# Altium schematic sheet MCU_HMI.SchDoc
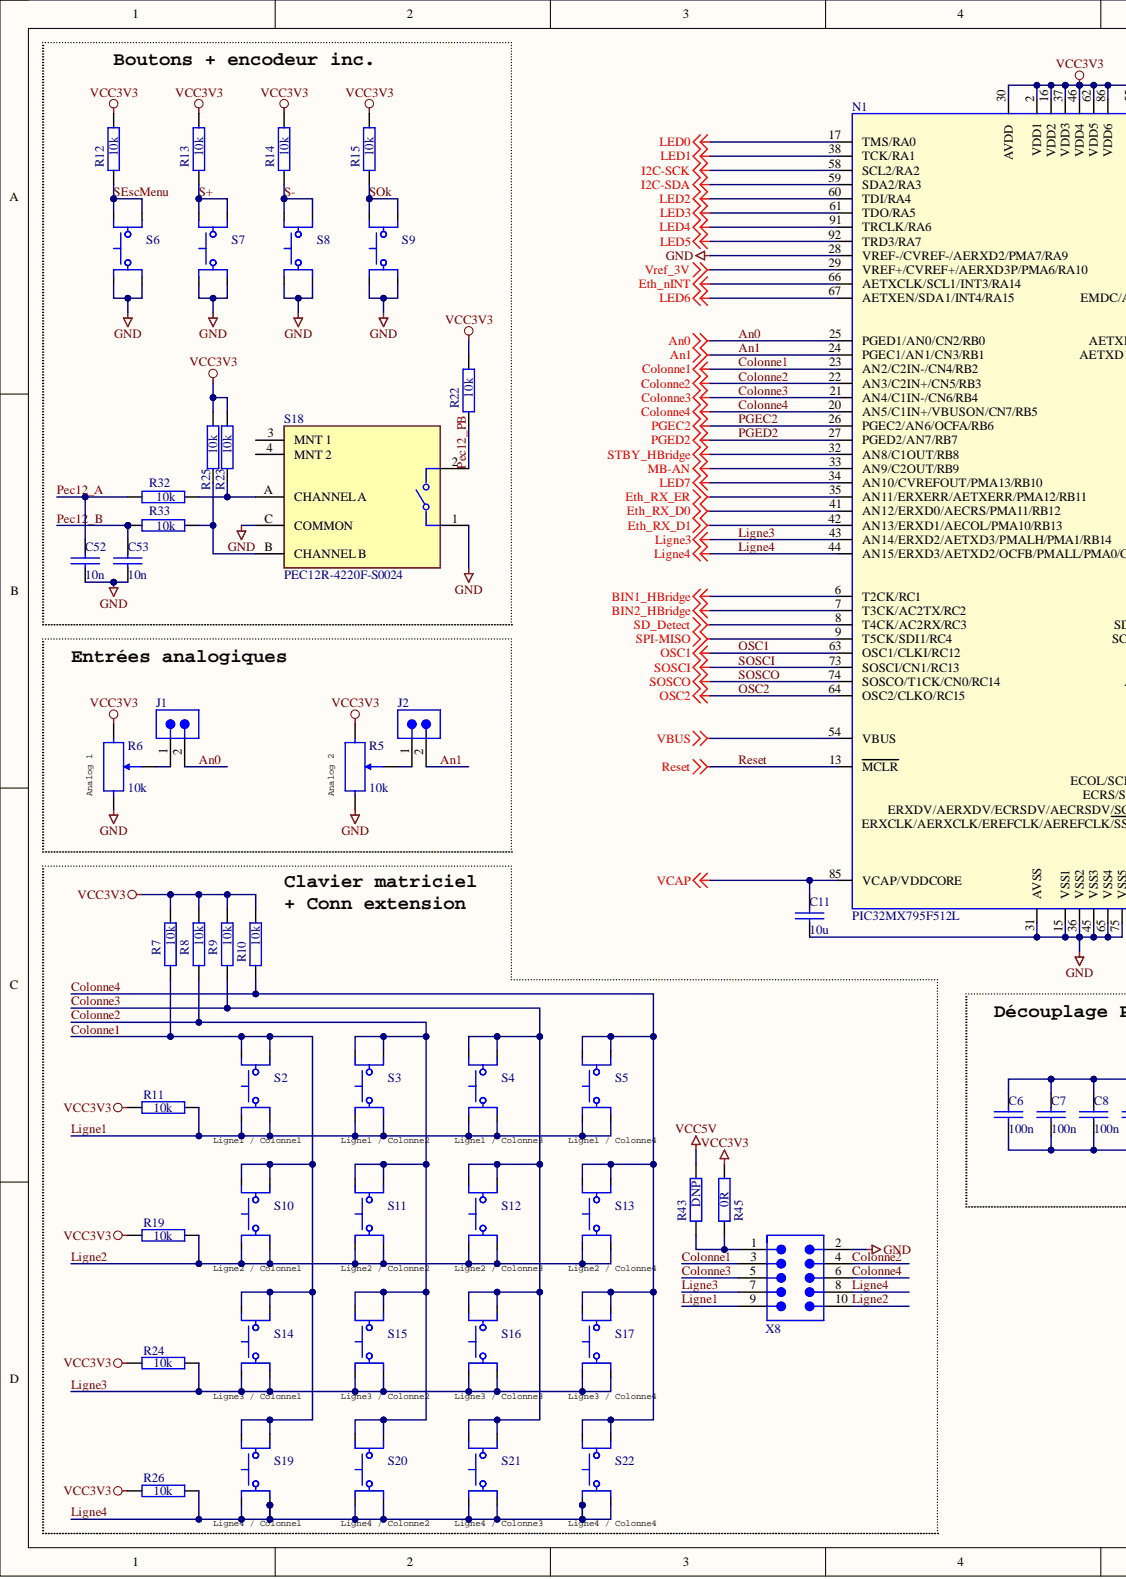
[diagram p0: MCU_HMI.SchDoc, left]
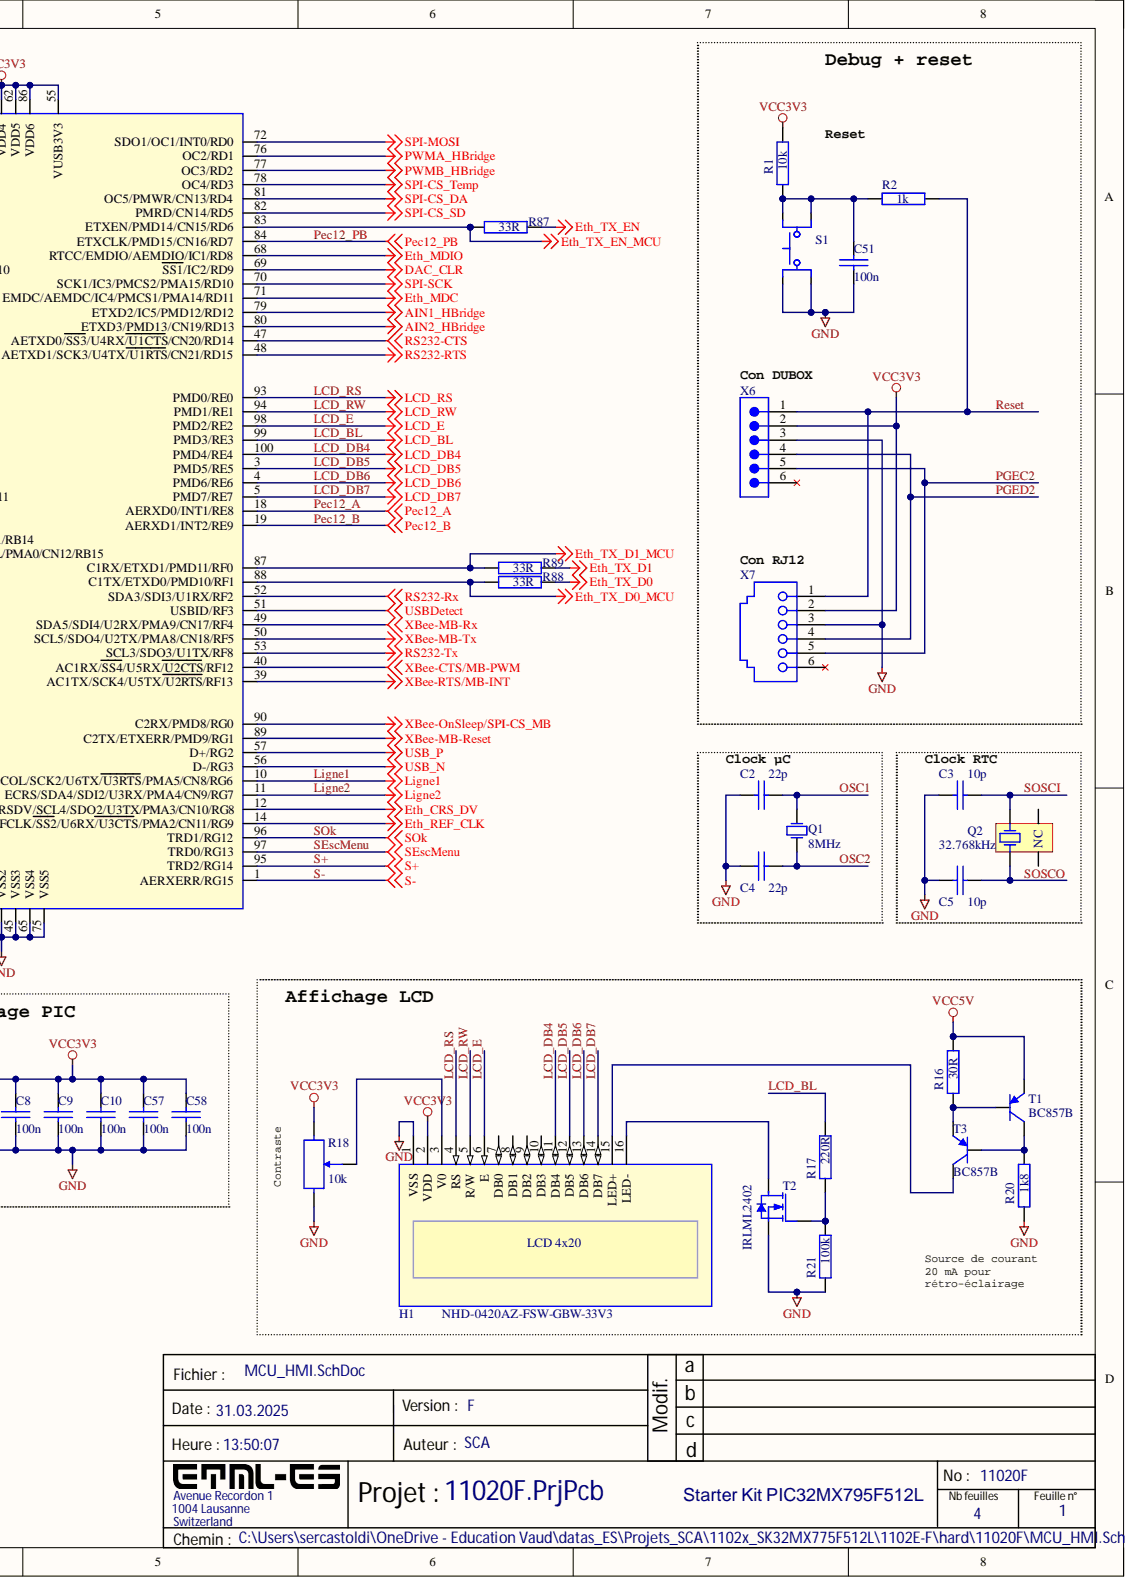
[diagram p1: MCU_HMI.SchDoc, right]

## Parts
C2  22p  [CAPC0805(2012)145_N]
C3  10p  [CAPC0805(2012)145_N]
C4  22p  [CAPC0805(2012)145_N]
C5  10p  [CAPC0805(2012)145_N]
C6  100n  [CAPC0805(2012)145_N]
C7  100n  [CAPC0805(2012)145_N]
C8  100n  [CAPC0805(2012)145_N]
C9  100n  [CAPC0805(2012)145_N]
C10  100n  [CAPC0805(2012)145_N]
C11  10u  [CAPC0805(2012)145_N]
C51  100n  [CAPC0805(2012)145_N]
C52  10n  [CAPC0805(2012)145_N]
C53  10n  [CAPC0805(2012)145_N]
C57  100n  [CAPC0805(2012)145_N]
C58  100n  [CAPC0805(2012)145_N]
H1  NHD-0420AZ-FSW-GBW-33V3  [NHD-0420AZ]
J1  61300211121  [61300211121]  (value not drawn)
J2  61300211121  [61300211121]  (value not drawn)
N1  PIC32MX795F512L  [TQFP-PT100_M]
Q1  Frequency: 8MHz  [HC-49/US-SM]  (hidden comment: ATS080BSM-1)
Q2  Frequency: 32.768kHz  [EPSON-MC-306_V]  (hidden comment: MC-306 32.7680K-A3: ROHS)
R1  10k  [RESC0805(2012)_N]
R2  1k  [RESC0805(2012)_N]
R5  10k  [POT_PT15NH05]
R6  10k  [POT_PT15NH05]
R7  10k  [RESC0805(2012)_N]
R8  10k  [RESC0805(2012)_N]
R9  10k  [RESC0805(2012)_N]
R10  10k  [RESC0805(2012)_N]
R11  10k  [RESC0805(2012)_N]
R12  10k  [RESC0805(2012)_N]
R13  10k  [RESC0805(2012)_N]
R14  10k  [RESC0805(2012)_N]
R15  10k  [RESC0805(2012)_N]
R16  30R  [RESC0805(2012)_N]
R17  220R  [RESC0805(2012)_N]
R18  10k  [BOUR-3362P]
R19  10k  [RESC0805(2012)_N]
R20  1k8  [RESC0805(2012)_N]
R21  100k  [RESC0805(2012)_N]
R22  10k  [RESC0805(2012)_N]
R23  10k  [RESC0805(2012)_N]
R24  10k  [RESC0805(2012)_N]
R25  10k  [RESC0805(2012)_N]
R26  10k  [RESC0805(2012)_N]
R32  10k  [RESC0805(2012)_N]
R33  10k  [RESC0805(2012)_N]
R43  DNP  [RESC0805(2012)_N]
R45  0R  [RESC0805(2012)_N]
R87  33R  [RESC0805(2012)_N]
R88  33R  [RESC0805(2012)_N]
R89  33R  [RESC0805(2012)_N]
S1  430182043816  [WS-TASV_L6W6H4.3_4301x20438x6]  (value not drawn)
S2  430182043816  [WS-TASV_L6W6H4.3_4301x20438x6]  (value not drawn)
S3  430182043816  [WS-TASV_L6W6H4.3_4301x20438x6]  (value not drawn)
S4  430182043816  [WS-TASV_L6W6H4.3_4301x20438x6]  (value not drawn)
S5  430182043816  [WS-TASV_L6W6H4.3_4301x20438x6]  (value not drawn)
S6  430182043816  [WS-TASV_L6W6H4.3_4301x20438x6]  (value not drawn)
S7  430182043816  [WS-TASV_L6W6H4.3_4301x20438x6]  (value not drawn)
S8  430182043816  [WS-TASV_L6W6H4.3_4301x20438x6]  (value not drawn)
S9  430182043816  [WS-TASV_L6W6H4.3_4301x20438x6]  (value not drawn)
S10  430182043816  [WS-TASV_L6W6H4.3_4301x20438x6]  (value not drawn)
S11  430182043816  [WS-TASV_L6W6H4.3_4301x20438x6]  (value not drawn)
S12  430182043816  [WS-TASV_L6W6H4.3_4301x20438x6]  (value not drawn)
S13  430182043816  [WS-TASV_L6W6H4.3_4301x20438x6]  (value not drawn)
S14  430182043816  [WS-TASV_L6W6H4.3_4301x20438x6]  (value not drawn)
S15  430182043816  [WS-TASV_L6W6H4.3_4301x20438x6]  (value not drawn)
S16  430182043816  [WS-TASV_L6W6H4.3_4301x20438x6]  (value not drawn)
S17  430182043816  [WS-TASV_L6W6H4.3_4301x20438x6]  (value not drawn)
S18  PEC12R-4220F-S0024  [FP-PEC12R-4220F-S0024-MFG]
S19  430182043816  [WS-TASV_L6W6H4.3_4301x20438x6]  (value not drawn)
S20  430182043816  [WS-TASV_L6W6H4.3_4301x20438x6]  (value not drawn)
S21  430182043816  [WS-TASV_L6W6H4.3_4301x20438x6]  (value not drawn)
S22  430182043816  [WS-TASV_L6W6H4.3_4301x20438x6]  (value not drawn)
T1  BC857B  [FP-SOT23-IPC_A]
T2  IRLML2402  [FP-SOT23-IPC_A]
T3  BC857B  [FP-SOT23-IPC_A]
X6  76384-406LF  [Dubox_76384-406LF]  (value not drawn)
X7  615006138421  [615006138421_CUSTOMES]  (value not drawn)
X8  61201021621  [61201021621]  (value not drawn)

## Nets
AIN1_HBridge: N1.79(ETXD2/IC5/PMD12/RD12)
AIN2_HBridge: N1.80(ETXD3/PMD13/CN19/RD13)
BIN1_HBridge: N1.6(T2CK/RC1)
BIN2_HBridge: N1.7(T3CK/AC2TX/RC2)
DAC_CLR: N1.69(SS1/IC2/RD9)
Eth_CRS_DV: N1.12(ERXDV/AERXDV/ECRSDV/AECRSDV/SCL4/SDO2/U3TX/PMA3/CN10/RG8)
Eth_MDC: N1.71(EMDC/AEMDC/IC4/PMCS1/PMA14/RD11)
Eth_MDIO: N1.68(RTCC/EMDIO/AEMDIO/IC1/RD8)
Eth_nINT: N1.66(AETXCLK/SCL1/INT3/RA14)
Eth_REF_CLK: N1.14(ERXCLK/AERXCLK/EREFCLK/AEREFCLK/SS2/U6RX/U3CTS/PMA2/CN11/RG9)
Eth_RX_D0: N1.41(AN12/ERXD0/AECRS/PMA11/RB12)
Eth_RX_D1: N1.42(AN13/ERXD1/AECOL/PMA10/RB13)
Eth_RX_ER: N1.35(AN11/ERXERR/AETXERR/PMA12/RB11)
Eth_TX_D0: R88.2
Eth_TX_D0_MCU: N1.88(C1TX/ETXD0/PMD10/RF1) R88.1
Eth_TX_D1: R89.2
Eth_TX_D1_MCU: N1.87(C1RX/ETXD1/PMD11/RF0) R89.1
Eth_TX_EN: R87.2
Eth_TX_EN_MCU: N1.83(ETXEN/PMD14/CN15/RD6) R87.1
GND: C2.1 C3.1 C4.1 C5.1 C6.2 C7.2 C8.2 C9.2 C10.2 C11.1 C51.2 C52.2 C53.2 C57.2 C58.2 H1.1(VSS) N1.15(VSS1) N1.28(VREF-/CVREF-/AERXD2/PMA7/RA9) N1.31(AVSS) N1.36(VSS2) N1.45(VSS3) N1.65(VSS4) N1.75(VSS5) R5.1 R6.1 R18.1 R20.1 R21.1 S1.1 S1.3 S6.1 S6.3 S7.1 S7.3 S8.1 S8.3 S9.1 S9.3 S18.1 S18.C(COMMON) T2.2 X6.3 X7.3 X8.2
I2C-SCK: N1.58(SCL2/RA2)
I2C-SDA: N1.59(SDA2/RA3)
LED0: N1.17(TMS/RA0)
LED1: N1.38(TCK/RA1)
LED2: N1.60(TDI/RA4)
LED3: N1.61(TDO/RA5)
LED4: N1.91(TRCLK/RA6)
LED5: N1.92(TRD3/RA7)
LED6: N1.67(AETXEN/SDA1/INT4/RA15)
LED7: N1.34(AN10/CVREFOUT/PMA13/RB10)
MB-AN: N1.33(AN9/C2OUT/RB9)
PWMA_HBridge: N1.76(OC2/RD1)
PWMB_HBridge: N1.77(OC3/RD2)
RS232-CTS: N1.47(AETXD0/SS3/U4RX/U1CTS/CN20/RD14)
RS232-RTS: N1.48(AETXD1/SCK3/U4TX/U1RTS/CN21/RD15)
RS232-Rx: N1.52(SDA3/SDI3/U1RX/RF2)
RS232-Tx: N1.53(SCL3/SDO3/U1TX/RF8)
SD_Detect: N1.8(T4CK/AC2RX/RC3)
SPI-CS_DA: N1.81(OC5/PMWR/CN13/RD4)
SPI-CS_SD: N1.82(PMRD/CN14/RD5)
SPI-CS_Temp: N1.78(OC4/RD3)
SPI-MISO: N1.9(T5CK/SDI1/RC4)
SPI-MOSI: N1.72(SDO1/OC1/INT0/RD0)
SPI-SCK: N1.70(SCK1/IC3/PMCS2/PMA15/RD10)
STBY_HBridge: N1.32(AN8/C1OUT/RB8)
USB_N: N1.56(D-/RG3)
USB_P: N1.57(D+/RG2)
USBDetect: N1.51(USBID/RF3)
VBUS: N1.54(VBUS)
VCAP: C11.2 N1.85(VCAP/VDDCORE)
VCC3V3: C6.1 C7.1 C8.1 C9.1 C10.1 C57.1 C58.1 H1.2(VDD) N1.2(VDD1) N1.16(VDD2) N1.30(AVDD) N1.37(VDD3) N1.46(VDD4) N1.55(VUSB3V3) N1.62(VDD5) N1.86(VDD6) R1.1 R5.3 R6.3 R7.2 R8.2 R9.2 R10.2 R11.1 R12.1 R13.1 R14.1 R15.1 R18.3 R19.1 R22.1 R23.1 R24.1 R25.1 R26.1 R45.2 X6.2 X7.2
VCC5V: R16.2 R43.2 T1.2
Vref_3V: N1.29(VREF+/CVREF+/AERXD3P/PMA6/RA10)
XBee-CTS/MB-PWM: N1.40(AC1RX/SS4/U5RX/U2CTS/RF12)
XBee-MB-Reset: N1.89(C2TX/ETXERR/PMD9/RG1)
XBee-MB-Rx: N1.49(SDA5/SDI4/U2RX/PMA9/CN17/RF4)
XBee-MB-Tx: N1.50(SCL5/SDO4/U2TX/PMA8/CN18/RF5)
XBee-OnSleep/SPI-CS_MB: N1.90(C2RX/PMD8/RG0)
XBee-RTS/MB-INT: N1.39(AC1TX/SCK4/U5TX/U2RTS/RF13)
An0: J1.2 N1.25(PGED1/AN0/CN2/RB0)
An1: J2.2 N1.24(PGEC1/AN1/CN3/RB1)
Colonne1: N1.23(AN2/C2IN-/CN4/RB2) R7.1 S2.2 S2.4 S10.2 S10.4 S14.2 S14.4 S19.2 S19.4 X8.3
Colonne2: N1.22(AN3/C2IN+/CN5/RB3) R8.1 S3.2 S3.4 S11.2 S11.4 S15.2 S15.4 S20.2 S20.4 X8.4
Colonne3: N1.21(AN4/C1IN-/CN6/RB4) R9.1 S4.2 S4.4 S12.2 S12.4 S16.2 S16.4 S21.2 S21.4 X8.5
Colonne4: N1.20(AN5/C1IN+/VBUSON/CN7/RB5) R10.1 S5.2 S5.4 S13.2 S13.4 S17.2 S17.4 S22.2 S22.4 X8.6
LCD_BL: N1.99(PMD3/RE3) R17.2
LCD_DB4: H1.11(DB4) N1.100(PMD4/RE4)
LCD_DB5: H1.12(DB5) N1.3(PMD5/RE5)
LCD_DB6: H1.13(DB6) N1.4(PMD6/RE6)
LCD_DB7: H1.14(DB7) N1.5(PMD7/RE7)
LCD_E: H1.6(E) N1.98(PMD2/RE2)
LCD_RS: H1.4(RS) N1.93(PMD0/RE0)
LCD_RW: H1.5(R/W) N1.94(PMD1/RE1)
Ligne1: N1.10(ECOL/SCK2/U6TX/U3RTS/PMA5/CN8/RG6) R11.2 S2.1 S2.3 S3.1 S3.3 S4.1 S4.3 S5.1 S5.3 X8.9
Ligne2: N1.11(ECRS/SDA4/SDI2/U3RX/PMA4/CN9/RG7) R19.2 S10.1 S10.3 S11.1 S11.3 S12.1 S12.3 S13.1 S13.3 X8.10
Ligne3: N1.43(AN14/ERXD2/AETXD3/PMALH/PMA1/RB14) R24.2 S14.1 S14.3 S15.1 S15.3 S16.1 S16.3 S17.1 S17.3 X8.7
Ligne4: N1.44(AN15/ERXD3/AETXD2/OCFB/PMALL/PMA0/CN12/RB15) R26.2 S19.1 S19.3 S20.1 S20.3 S21.1 S21.3 S22.1 S22.3 X8.8
OSC1: C2.2 N1.63(OSC1/CLKI/RC12) Q1.2
OSC2: C4.2 N1.64(OSC2/CLKO/RC15) Q1.1
Pec12_A: C52.1 N1.18(AERXD0/INT1/RE8) R32.2
Pec12_B: C53.1 N1.19(AERXD1/INT2/RE9) R33.2
Pec12_PB: N1.84(ETXCLK/PMD15/CN16/RD7) R22.2 S18.2
PGEC2: N1.26(PGEC2/AN6/OCFA/RB6) X6.5 X7.5
PGED2: N1.27(PGED2/AN7/RB7) X6.4 X7.4
Reset: N1.13(MCLR) R2.2 X6.1 X7.1
S+: N1.95(TRD2/RG14) R13.2 S7.2 S7.4
S-: N1.1(AERXERR/RG15) R14.2 S8.2 S8.4
SEscMenu: N1.97(TRD0/RG13) R12.2 S6.2 S6.4
SOk: N1.96(TRD1/RG12) R15.2 S9.2 S9.4
SOSCI: C3.2 N1.73(SOSCI/CN1/RC13) Q2.4
SOSCO: C5.2 N1.74(SOSCO/T1CK/CN0/RC14) Q2.1
NetC51_1: C51.1 R1.2 R2.1 S1.2 S1.4
NetH1_3: H1.3(V0) R18.2
NetH1_15: H1.15(LED+) T3.3
NetH1_16: H1.16(LED-) T2.3
NetJ1_1: J1.1 R6.2
NetJ2_1: J2.1 R5.2
NetR16_1: R16.1 T1.1 T3.2
NetR17_1: R17.1 R21.2 T2.1
NetR20_2: R20.2 T1.3 T3.1
NetR23_2: R23.2 R32.1 S18.A(CHANNEL_A)
NetR25_2: R25.2 R33.1 S18.B(CHANNEL_B)
NetR43_1: R43.1 R45.1 X8.1

Not connected: H1 7(DB0) 8(DB1) 9(DB2) 10(DB3); Q2 2(NC) 3; S18 3(MNT_1) 4(MNT_2); X6 6; X7 6

## Library data of the parts
C2-C11 C51-C53 C57 C58 = C: Component Kind: Standard
  C2 C4: Value: 22p
  C3 C5: Value: 10p
  C6-C10 C51 C57 C58: Value: 100n
  C11: Value: 10u
  C52 C53: Value: 10n
H1 = LCD_NHD-0420AZ-FSW-GBW-33V3: New Haven Display 4X20 Character LCD, STN+ Gray Display with White Side Backlight 3V; Component Kind: Standard; Library Name: 11020E.SCHLIB
J1 J2 = 61300211121: WR-PHD Pin Header, THT, Vertical, pitch 2.54mm, 1 Row, 2P; Manufacturer: Wurth Elektronik; Contact Resistance: 20mΩ; Rated Current: 3A; Withstanding Voltage: 500V; Working Voltage: 250V; Category: Board-to-Board Connectors; Component Kind: Standard; ComponentLink1Description: Website Link; ComponentLink1URL: https://www.we-online.com/redexpert/article/61300211121?ad; ComponentLink2Description: Datasheet Link; ComponentLink2URL: https://www.we-online.com/redexpert/spec/61300211121?ad; Gender: Pin Header; Library Name: Altium_WR-PHD (rev22b).IntLib; Manufacturer Part Number: 61300211121; Match Code: WR-PHD; Mount: Through Hole; Number of Pins: 2; Number of Rows: 1; Operating Temperature Max: 105°C; Operating Temperature Min: -40°C; Orientation: Vertical; Packaging: Bag; Pitch: 2.54mm
Q1 = XTAL-ATS080BSM-1: 8 MHz; Manufacturer: CTS-Frequency Controls; ESR (Equivalent Series Resistance): 60Ohm; Frequency Stability: 50ppm; Frequency Tolerance: 30ppm; Load Capacitance: 18pF; Case/Package: SMD/SMT; Component Kind: Standard; Lead Free: LeadFree; Library Name: KitArmM0.SCHLIB; Max Operating Temperature: 70degC; Min Operating Temperature: -20degC; Mount: SurfaceMount; Number of Pins: 2; Package Description: 2-Pin Surface Mount Device, Body 5 x 11.7 mm; Package Quantity: 1000; Package Reference: HC49/US-SM; Packaging: TapeandReel; Radiation Hardening: No; REACH SVHC: NoSVHC; RoHSCompliant: True; Series Resistance: 60Ohm; Supplier: Digikey,Stock ES; Supplier min. qty: 1; Supplier Ref.: CTX884CT-ND; Supplier unit price: 0.39; Termination: SMD/SMT; Tolerance: 30ppm; Value: ATS080BSM-1
Q2 = MC-306 32.7680K-A0: Crystal, 32.768 kHz, 12.5 pF, 50 KOhm, -40 to 85 degC, 4-Pin SMD, RoHS, Tape and Reel; Manufacturer: Epson; Catalog Drawings: MC-306SeriesSide  MC-306SeriesTop  MC-306SeriesCircuit; Dynamic Catalog: MC-306,MC-405andMC-406Series; ESR (Equivalent Series Resistance): 50kOhm; Frequency Tolerance: ±20ppm; Load Capacitance: 12.5pF; Operating Mode: Fundamental; Product Training Modules: Crystals; Type: kHzCrystal(TuningFork); Category: CrystalsandOscillators; Component Kind: Standard; Datasheet URL: https://support.epson.biz/td/api/doc_check.php?dl=brief_MC-306_en.pdf; Datasheet Version: 01/2014; Family: Crystals; Library Name: 11020E.SCHLIB; Manufacturer URL: https://www.eea.epson.com/; Mounting Technology: SurfaceMount; Mounting Type: SurfaceMount; Operating Temperature: -40°C~85°C; Package / Case: 4-SOJ,5.50mmpitch; Package Description: 4-Pin Surface Mount Device, Body 8 x 3.2 mm; Package Reference: MC-306; Packaging: Tape&Reel(TR); Series: MC-306; Standard Package: 1,000; Supplier: Digikey; Supplier min. qty: 1; Supplier Ref.: SER4306CT-ND; Supplier unit price: 1.61; Value: MC-306 32.7680K-A3: ROHS
R1 R2 R7-R17 R19-R26 R32 R33 R43 R45 R87-R89 = R: Component Kind: Standard
  R1 R7-R15 R19 R22-R26 R32 R33: Value: 10k
  R2: Value: 1k
  R16: Value: 30R
  R17: Value: 220R
  R20: Value: 1k8
  R21: Value: 100k
  R43: Value: DNP
  R45: Value: 0R
  R87-R89: Value: 33R
R5 R6 = POT: Piher PT15NH05-103A2020-S; Component Kind: Standard; Value: 10k
R18 = POT: Bourns Trimmer Resistors - Through Hole 1/4"SQ 10KOHMS 10% 0.5WATTS 3362P-1-103LF; Component Kind: Standard; Value: 10k
S1-S17 S19-S22 = 430182043816: WS-TASV SMT Tact Switch, L6 x W6 x H4.3mm, 160g, SPST-NO, 12V, 50mA; Manufacturer: Wurth Elektronik; Washable: No; Category: Tact Switches; Circuit: SPST-NO; Component Kind: Standard; ComponentLink1Description: Website Link; ComponentLink1URL: https://www.we-online.com/redexpert/article/430182043816?ad; ComponentLink2Description: Datasheet Link; ComponentLink2URL: https://www.we-online.com/redexpert/spec/430182043816?ad; Contact Material: Stainless Steel; Contact Plating: Silver; Height: 4.3mm; Length: 6mm; Library Name: 11020E.SCHLIB; Manufacturer Part Number: 430182043816; Match Code: WS-TASV; Mount: Surface Mount; Operating Temperature Max: 85°C; Operating Temperature Min: -40°C; Operation Force: 160g; Packaging: Tape and Reel; Rated Current: 50mA; Rated Voltage (DC): 12V; Terminal Material: Copper Alloy; Terminal Plating: Silver; Width: 6mm
S18 = CMP-07421-000021-1: ROTARY ENCODER MECHANICAL 24PPR; Built in Switch: Yes; Hole Diameter: 2mm; Max Supply Voltage (DC): 5V; Number of Channels: 2; Output Type: Quadrature; Pulses per Revolution: 24; Component Kind: Standard; Detent: Yes; Encoder Type: Mechanical; Height: 13.4mm; Length: 26mm; Library Name: Altium Content Vault; Max Operating Temperature: 70°C; Min Operating Temperature: -30°C; Mount: Through Hole; Orientation: Vertical; Package Quantity: 150; Packaging: Bulk; REACH SVHC: No SVHC; RoHS Compliant: Yes; Series: PEC12R; Termination: PC Pin; Width: 12.5mm
T1 T3 = ONSC-PNP-B1E2C3-3: General Purpose Transistor, PNP Silicon, 3-Pin SOT-23, Pb-Free; fT Min (MHz): 100; IC Continuous (A): -0.1; Packing: Tape and Reel; Component Kind: Standard; ComponentLink1Description: Manufacturer URL; ComponentLink2Description: Datasheet; ComponentLink3Description: Package Specification; Library Name: 11020E.SCHLIB; Mounting Technology: Surface Mount; PartNumber: BC857B; Polarity: PNP; RoHS: TRUE
T2 = NEMOS-GSD-3_A: HEXFET(R) Power MOSFET, N-Channel, 20 V, 1.2 A, 3-Pin SOT-23, RoHS, Tape and Reel; Manufacturer: International Rectifier; Continuous Drain Current Id: 1.2A; Current Temperature: 25degC; Drain Source Voltage Vds: 20V; External Depth: 2.5mm; External Length / Height: 1.12mm; External Width: 3.05mm; Junction Temperature Tj Min: -55degC; No. of Pins: 3; No. of Transistors: 1; On Resistance Rds(on): 250mohm; Power Dissipation Pd: 540mW; Pulse Current Idm: 7.4A; Rds(on) Test Voltage Vgs: 4.5V; Reel Quantity: 3000; SMD Marking: 1A; SVHC: NoSVHC(19-Dec-2012); Threshold Voltage Vgs Typ: 700mV; Transistor Polarity: NChannel; Component Kind: Standard; Current Id Max: 1.2A; Datasheet URL: https://datasheet.ciiva.com/29326/162826-da-01-en-irlml-2402tr-29326791.pdf; Datasheet Version: Jan-03; Full Power Rating Temperature: 25degC; Library Name: 11020E.SCHLIB; Manufacturer URL: http://internationalrectifier.com/; Operating Temperature Range: -55degCto+150degC; Package / Case: SOT-23; Tape Width: 8mm; Termination Type: SMD; Transistor Case Style: SOT-23; Voltage Vds Typ: 20V; Voltage Vgs Max: 700mV; Voltage Vgs Rds on Measurement: 4.5V; Voltage Vgs th Min: 700mV
X6 = Dubox_76384-406LF: Connector Type: Male Pin; Current Rating: 3A; Gender: Male; Number of Contacts: 6; Operating Supply Voltage: 1000V; Pitch: 2.54mm; Color: Black; Component Kind: Standard; Contact Gender: Male; Height: 9.34mm; Lead Pitch: 2.54mm; Library Name: 11020E.SCHLIB; Max Operating Temperature: 125°C; Min Operating Temperature: -40°C; Mount: Through Hole; Number of Pins: 6; Number of Positions: 6; Number of Rows: 1; Orientation: Vertical; Termination: Through Hole; Voltage Rating: 1000V
X7 = 615006138421: WR-MJ Modular Jack THT Horizontal Plastic 6P6C Tab Up; Manufacturer: Wurth Elektronik; Contact Resistance: 20mΩ; Insulation Resistance: 500MΩ; LED: No; Number of Ports: 1; Rated Current: 1.5A; Withstanding Voltage: 1000VAC; Working Voltage: 120VAC; Category: Input/Output Connectors; Component Kind: Standard; ComponentLink1Description: Website Link; ComponentLink1URL: https://www.we-online.com/redexpert/article/615006138421?ad; ComponentLink2Description: Datasheet Link; ComponentLink2URL: https://www.we-online.com/redexpert/spec/615006138421?ad; Library Name: Altium_WR-MJ (rev23a).IntLib; Manufacturer Part Number: 615006138421; Match Code: WR-MJ; Mount: Through Hole; Number of Pins: 6P6C; Operating Temperature Max: 85°C; Operating Temperature Min: -40°C; Orientation: Horizontal; Packaging: Tray; Shielding: No
X8 = 61201021621: WR-BHD Male Box Header, THT Straight, pitch 2.54mm, 2 row, 10 pin; Manufacturer: Wurth Elektronik; Contact Resistance: 20mOhm; Insulation Resistance: 1000MOhm; Rated Current: 3A; Withstanding Voltage: 500V; Working Voltage: 250V; Category: Wire-to-Board Connectors; Component Kind: Standard; ComponentLink1Description: Website Link; ComponentLink1URL: https://www.we-online.com/redexpert/article/61201021621?ad; ComponentLink2Description: Datasheet Link; ComponentLink2URL: https://www.we-online.com/redexpert/spec/61201021621?ad; Gender: Male; Height: 9.1mm; Length: 20.36mm; Library Name: WR-BHD.SchLib; Manufacturer Part Number: 61201021621; Match Code: WR-BHD; Mount: Through Hole; Number of Pins: 10; Number of Rows: 2; Operating Temperature Max: 105°C; Operating Temperature Min: -40°C; Orientation: Straight; Packaging: Tray; Pitch: 2.54mm; Width: 9.1mm
N1 = PIC32MX795F512L: Component Kind: Standard; Library Name: 11020E.SCHLIB
